# Altium schematic sheet MAGIsCM4Core.SchDoc
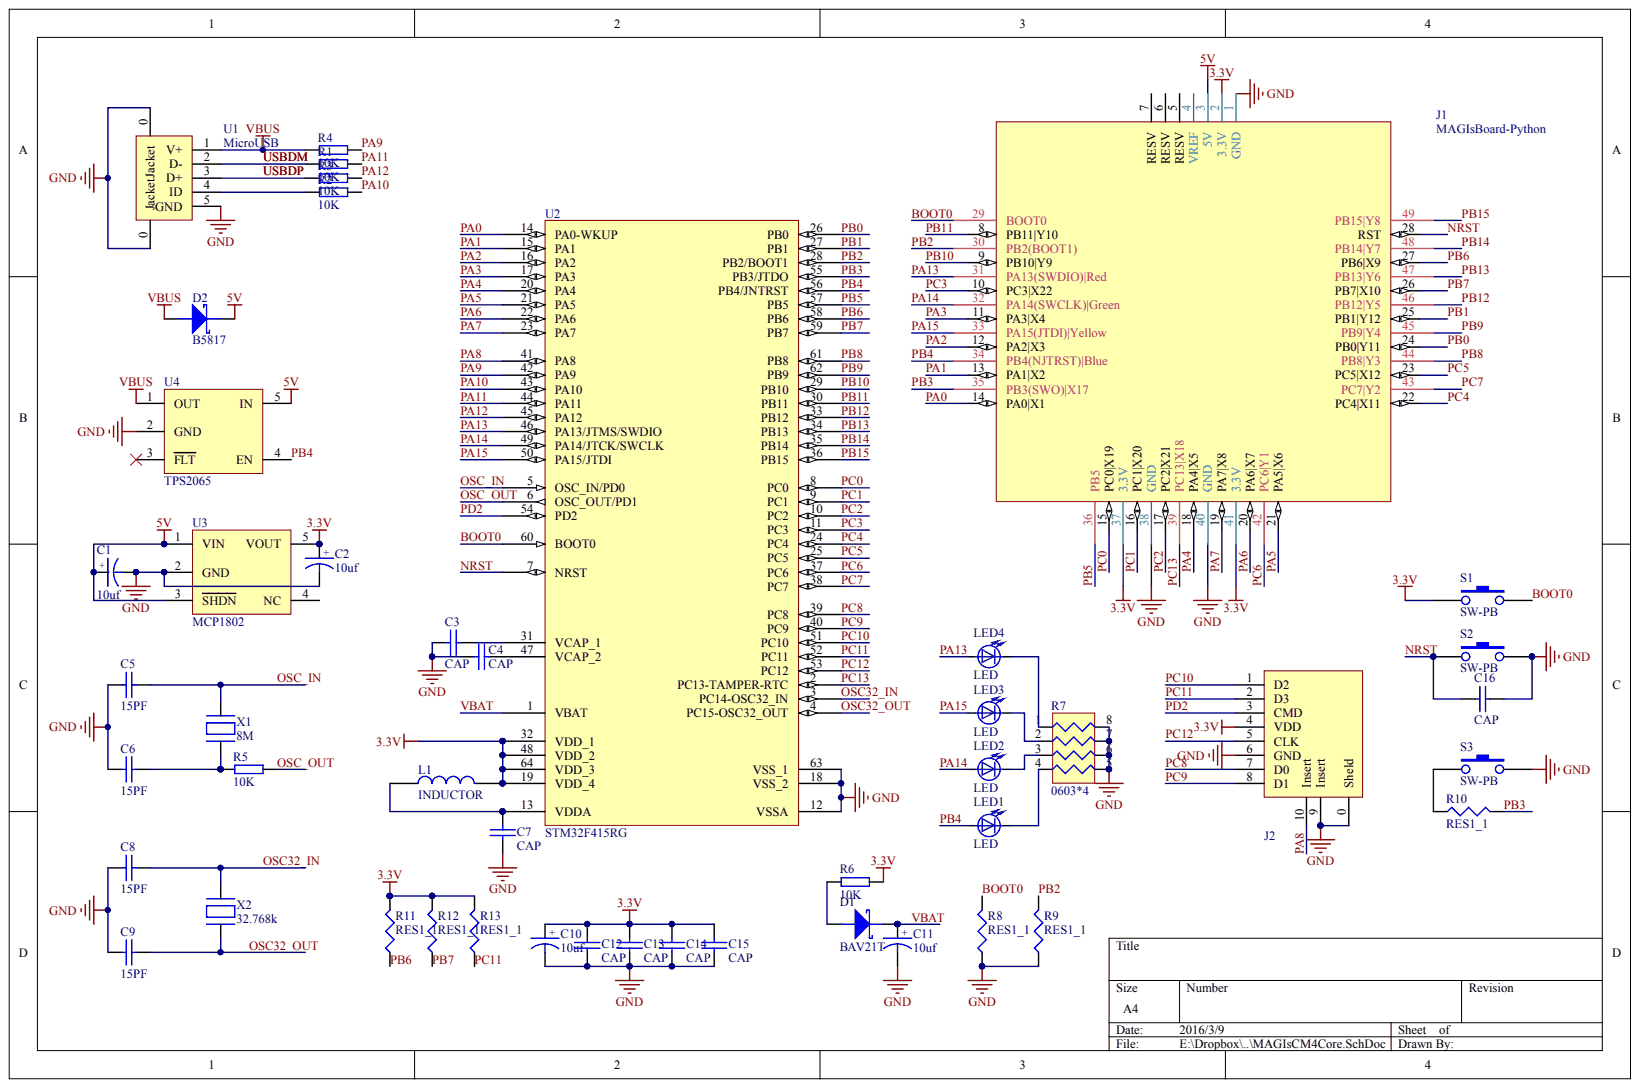
[diagram p0: MAGIsCM4Core.SchDoc]

## Parts
C1  10uf
C2  10uf
C3  CAP
C4  CAP
C5  15PF
C6  15PF
C7  CAP
C8  15PF
C9  15PF
C10  10uf
C11  10uf
C12  CAP
C13  CAP
C14  CAP
C15  CAP
C16  CAP
D1  BAV21T
D2  B5817
J1  MAGIsBoard-Python
J2  MicroSD-Short  (value not drawn)
L1  INDUCTOR
LED1  LED
LED2  LED
LED3  LED
LED4  LED
R1  10K
R2  10K
R3  10K
R4  10K
R5  10K
R6  10K
R7  0603*4
R8  RES1_1
R9  RES1_1
R10  RES1_1
R11  RES1_1
R12  RES1_1
R13  RES1_1
S1  SW-PB
S2  SW-PB
S3  SW-PB
U1  MicroUSB
U2  STM32F415RG
U3  MCP1802
U4  TPS2065
X1  8M
X2  32.768k

## Nets
3.3V: C2.1 C10.1 C12.2 C13.2 C14.2 C15.2 J1.2(3.3V) J1.37(3.3V) J1.41(3.3V) J2.4(VDD) L1.2 R6.1 R11.2 R12.2 R13.2 S1.1 U2.19(VDD_4) U2.32(VDD_1) U2.48(VDD_2) U2.64(VDD_3) U3.5(VOUT)
5V: C1.1 D2.2 J1.3(5V) U3.1(VIN) U3.3(SHDN) U4.5(IN)
GND: C1.2 C2.2 C3.1 C4.1 C5.1 C6.1 C7.2 C8.1 C9.1 C10.2 C11.2 C12.1 C13.1 C14.1 C15.1 C16.1 J1.1(GND) J1.38(GND) J1.40(GND) J2.0(Sheld) J2.6(GND) J2.9(Insert) R7.5 R7.6 R7.7 R7.8 R8.1 R9.1 S2.2 S3.2 U1.0(Jacket_Jacket) U1.5(GND) U2.12(VSSA) U2.18(VSS_2) U2.63(VSS_1) U3.2(GND) U4.2(GND)
VBUS: D2.1 R4.2 U1.1(V+) U4.1(OUT)
BOOT0: J1.29(BOOT0) R8.2 S1.2 U2.60(BOOT0)
NRST: C16.2 J1.28(RST) S2.1 U2.7(NRST)
OSC32_IN: C8.2 U2.3(PC14-OSC32_IN) X2.1
OSC32_OUT: C9.2 U2.4(PC15-OSC32_OUT) X2.2
OSC_IN: C5.2 U2.5(OSC_IN/PD0) X1.1
OSC_OUT: R5.1 U2.6(OSC_OUT/PD1)
PA0: J1.14(PA0|X1) U2.14(PA0-WKUP)
PA1: J1.13(PA1|X2) U2.15(PA1)
PA2: J1.12(PA2|X3) U2.16(PA2)
PA3: J1.11(PA3|X4) U2.17(PA3)
PA4: J1.18(PA4|X5) U2.20(PA4)
PA5: J1.21(PA5|X6) U2.21(PA5)
PA6: J1.20(PA6|X7) U2.22(PA6)
PA7: J1.19(PA7|X8) U2.23(PA7)
PA8: J2.10(Insert) U2.41(PA8)
PA9: R4.1 U2.42(PA9)
PA10: R2.1 U2.43(PA10)
PA11: R1.1 U2.44(PA11)
PA12: R3.1 U2.45(PA12)
PA13: J1.31(PA13(SWDIO)|Red) LED4.1 U2.46(PA13/JTMS/SWDIO)
PA14: J1.32(PA14(SWCLK)|Green) LED2.1 U2.49(PA14/JTCK/SWCLK)
PA15: J1.33(PA15(JTDI)|Yellow) LED3.1 U2.50(PA15/JTDI)
PB0: J1.24(PB0|Y11) U2.26(PB0)
PB1: J1.25(PB1|Y12) U2.27(PB1)
PB2: J1.30(PB2(BOOT1)) R9.2 U2.28(PB2/BOOT1)
PB3: J1.35(PB3(SWO)|X17) R10.2 U2.55(PB3/JTDO)
PB4: J1.34(PB4(NJTRST)|Blue) LED1.1 U2.56(PB4/JNTRST) U4.4(EN)
PB5: J1.36(PB5) U2.57(PB5)
PB6: J1.27(PB6|X9) R11.1 U2.58(PB6)
PB7: J1.26(PB7|X10) R12.1 U2.59(PB7)
PB8: J1.44(PB8|Y3) U2.61(PB8)
PB9: J1.45(PB9|Y4) U2.62(PB9)
PB10: J1.9(PB10|Y9) U2.29(PB10)
PB11: J1.8(PB11|Y10) U2.30(PB11)
PB12: J1.46(PB12|Y5) U2.33(PB12)
PB13: J1.47(PB13|Y6) U2.34(PB13)
PB14: J1.48(PB14|Y7) U2.35(PB14)
PB15: J1.49(PB15|Y8) U2.36(PB15)
PC0: J1.15(PC0|X19) U2.8(PC0)
PC1: J1.16(PC1|X20) U2.9(PC1)
PC2: J1.17(PC2|X21) U2.10(PC2)
PC3: J1.10(PC3|X22) U2.11(PC3)
PC4: J1.22(PC4|X11) U2.24(PC4)
PC5: J1.23(PC5|X12) U2.25(PC5)
PC6: J1.42(PC6|Y1) U2.37(PC6)
PC7: J1.43(PC7|Y2) U2.38(PC7)
PC8: J2.7(D0) U2.39(PC8)
PC9: J2.8(D1) U2.40(PC9)
PC10: J2.1(D2) U2.51(PC10)
PC11: J2.2(D3) R13.1 U2.52(PC11)
PC12: J2.5(CLK) U2.53(PC12)
PC13: J1.39(PC13|X18) U2.2(PC13-TAMPER-RTC)
PD2: J2.3(CMD) U2.54(PD2)
USBDM: R1.2 U1.2(D-)
USBDP: R3.2 U1.3(D+)
VBAT: C11.1 D1.2 U2.1(VBAT)
NetC3_2: C3.2 U2.31(VCAP_1)
NetC4_2: C4.2 U2.47(VCAP_2)
NetC6_2: C6.2 R5.2 X1.2
NetC7_1: C7.1 L1.1 U2.13(VDDA)
NetD1_1: D1.1 R6.2
NetLED1_2: LED1.2 R7.4
NetLED2_2: LED2.2 R7.3
NetLED3_2: LED3.2 R7.2
NetLED4_2: LED4.2 R7.1
NetR2_2: R2.2 U1.4(ID)
NetR10_1: R10.1 S3.1

Not connected: J1 4(VREF) 5(RESV) 6(RESV) 7(RESV); U3 4(NC); U4 3(FLT)
